# Altium schematic sheet 4) TEMP & WATCHDOG.SchDoc("4) TEMP & WATCHDOG")
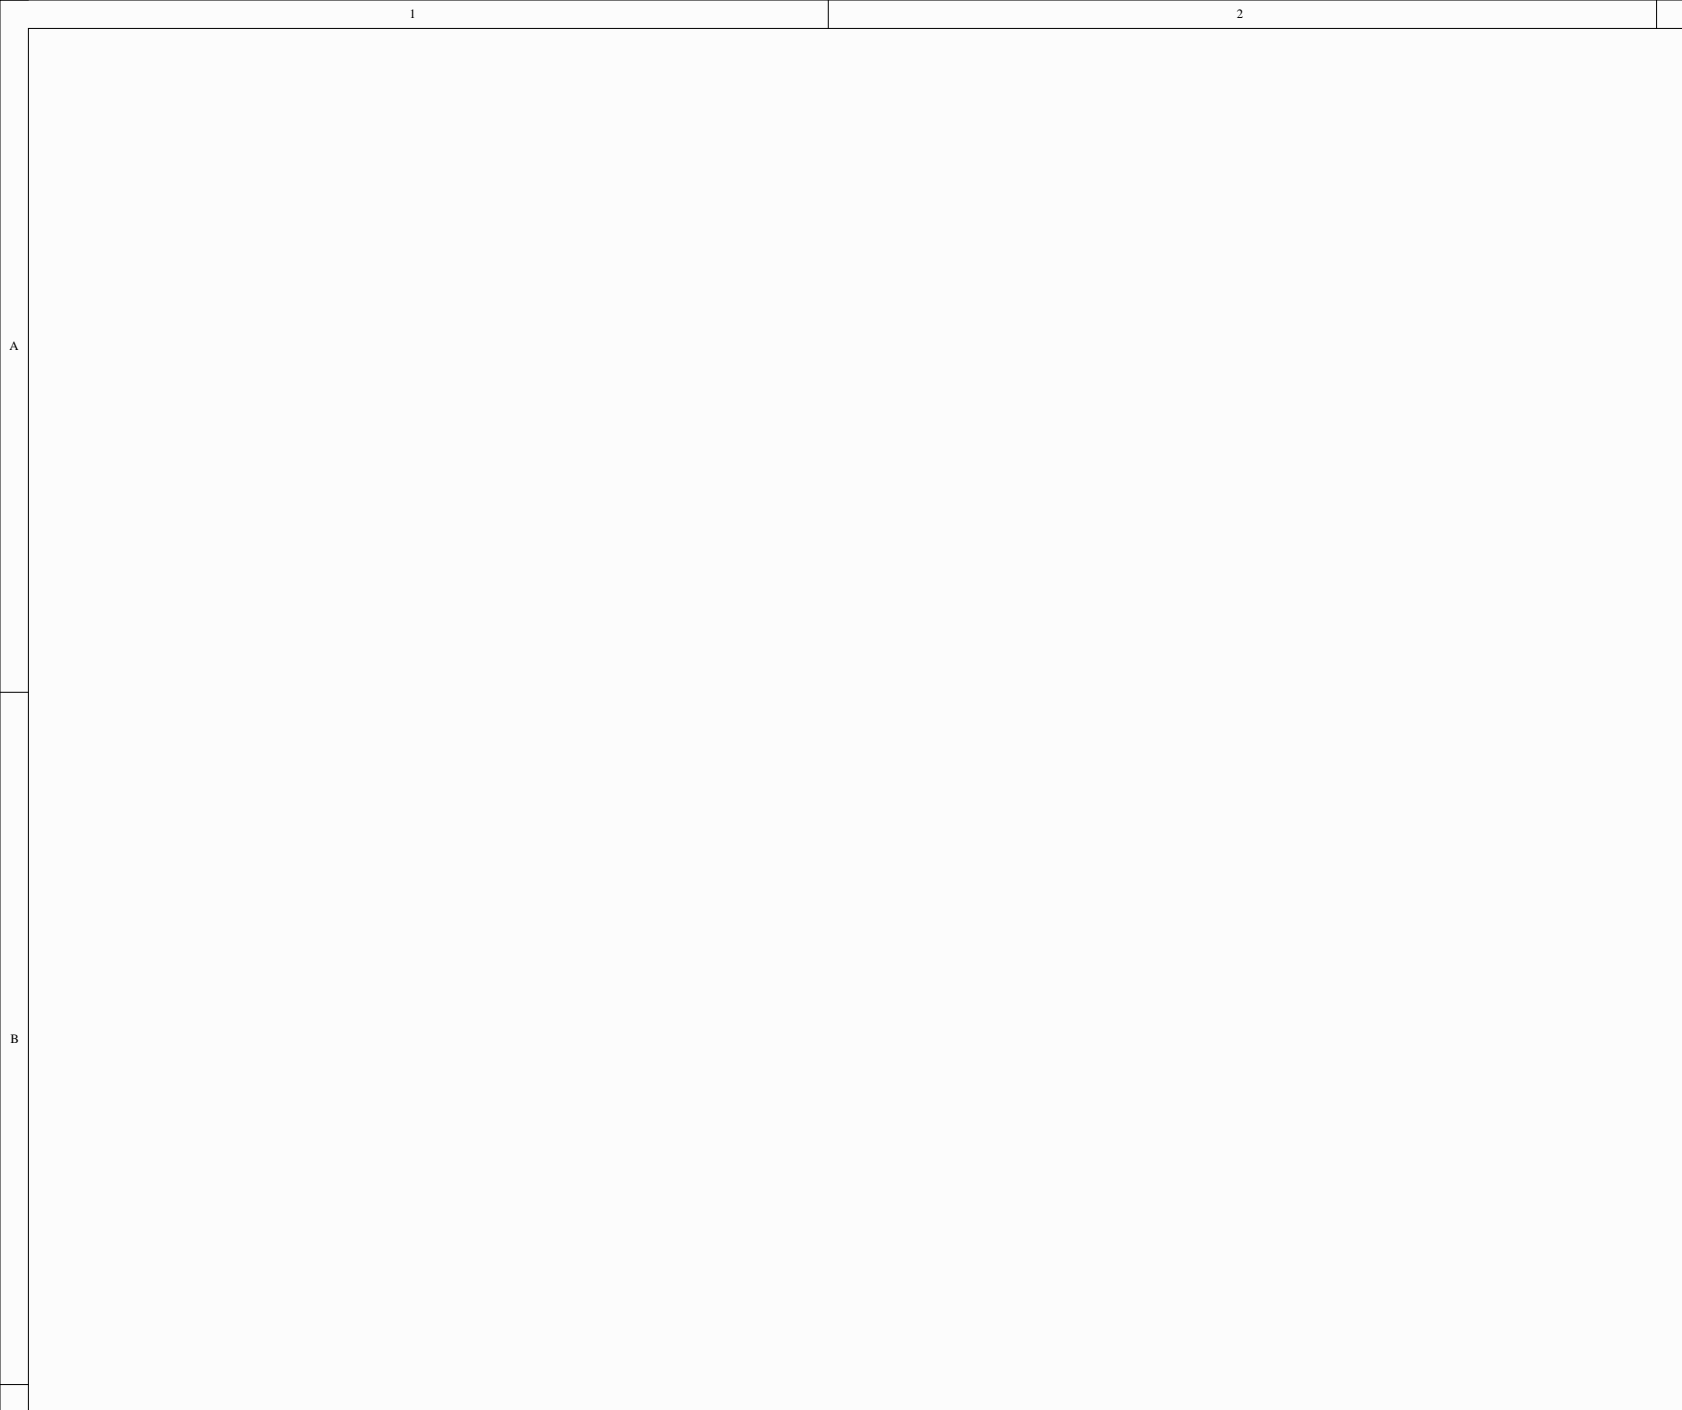
[diagram p0: 4) TEMP & WATCHDOG.SchDoc, top left]
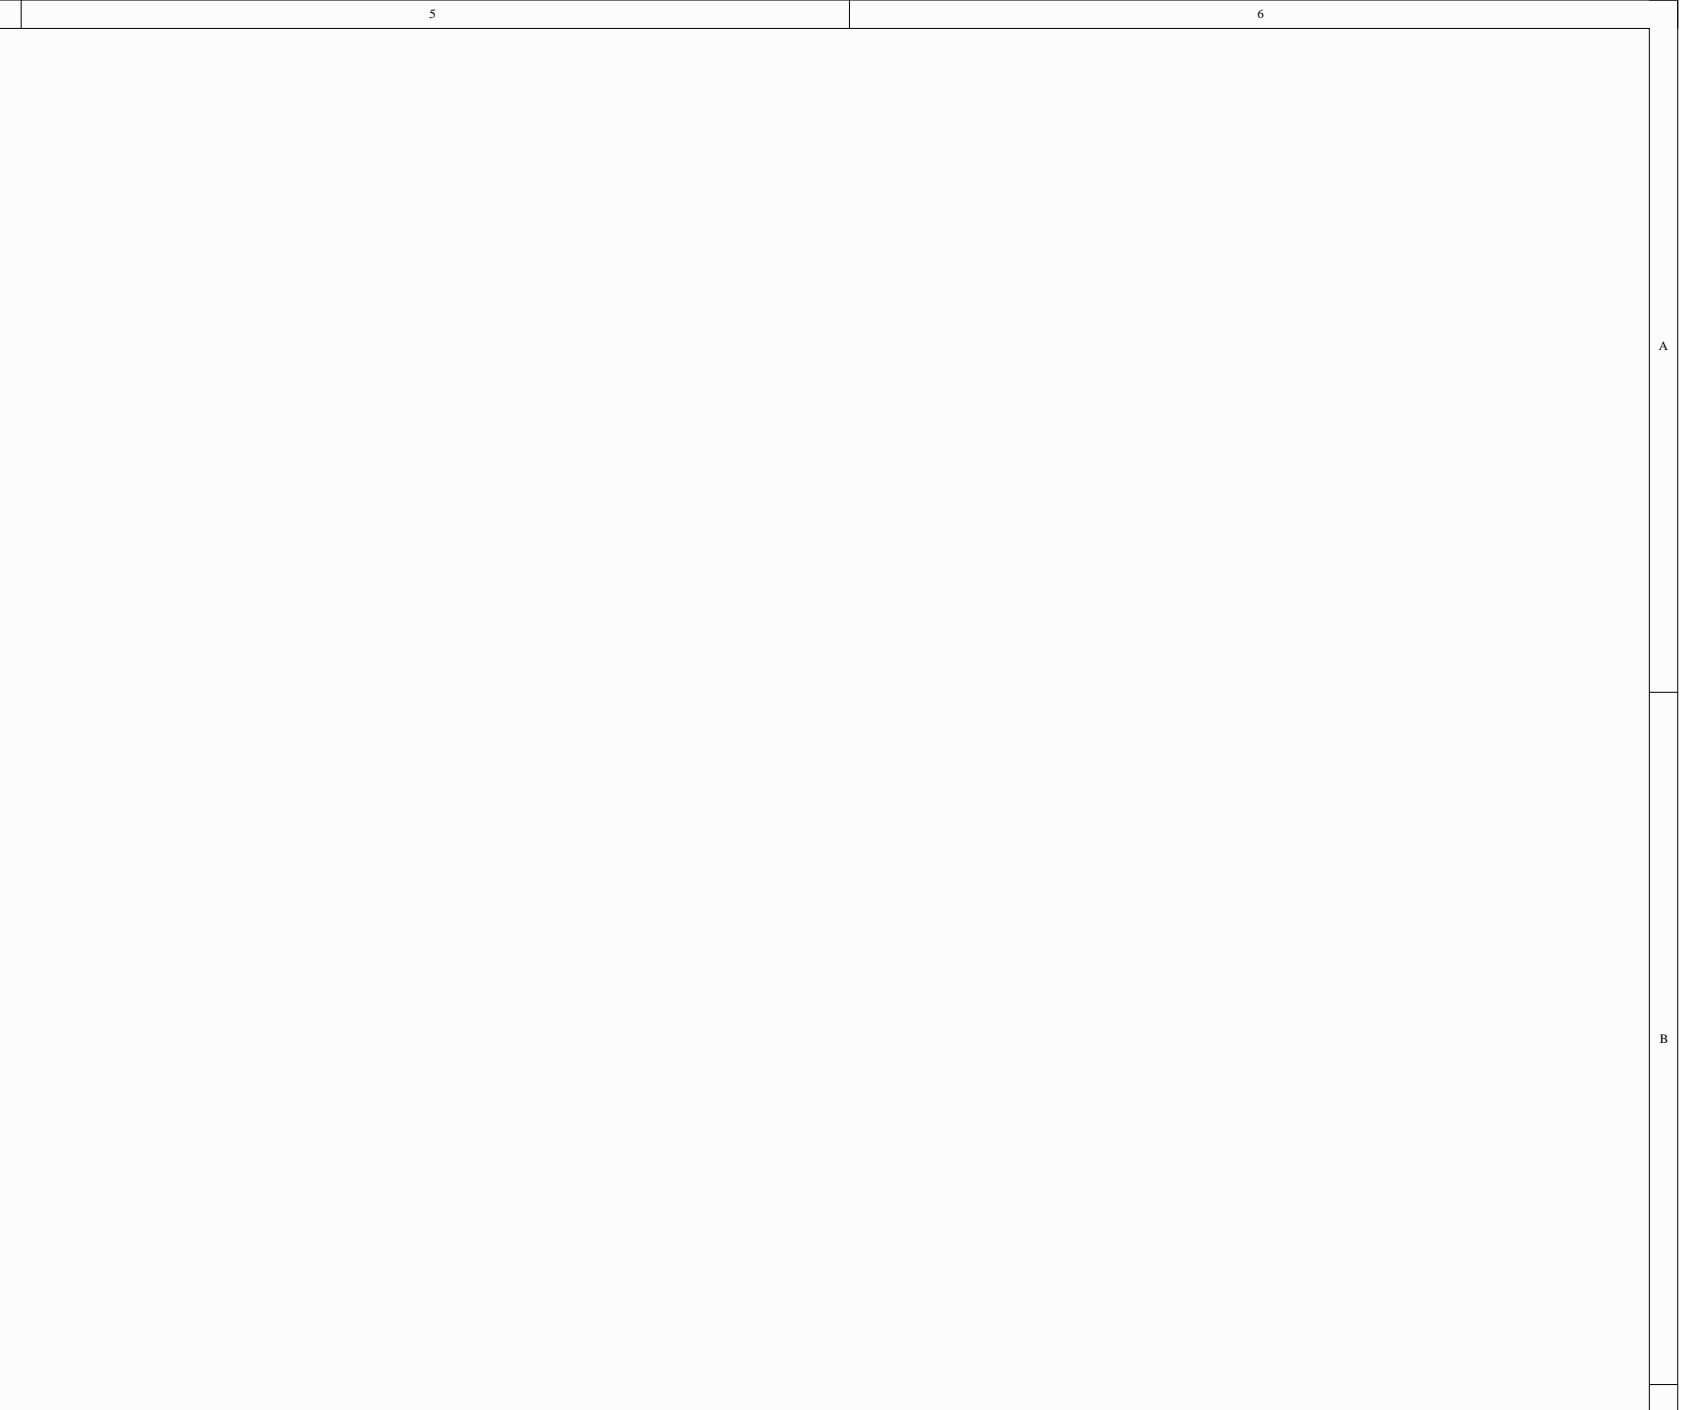
[diagram p1: 4) TEMP & WATCHDOG.SchDoc, top right]
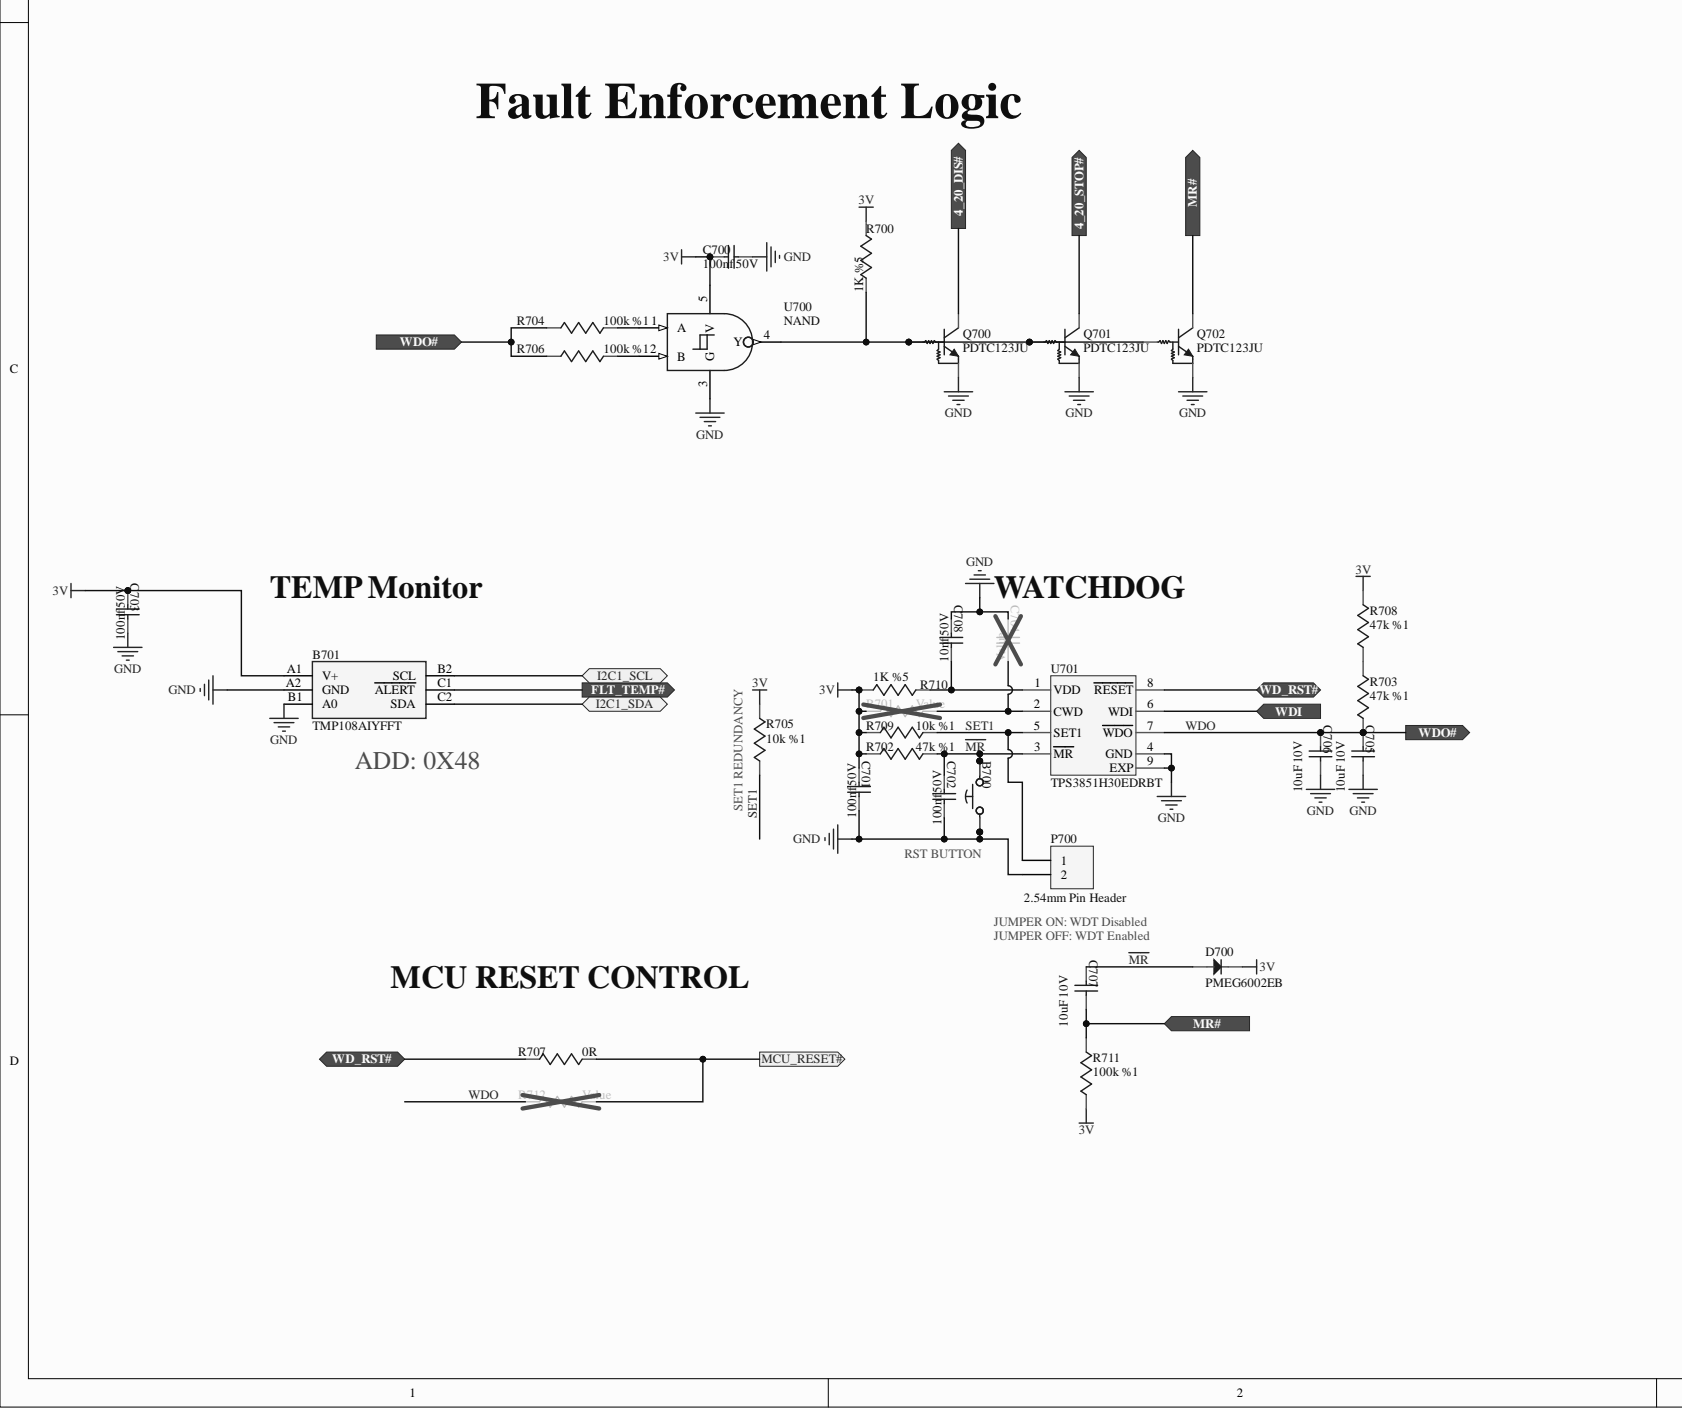
[diagram p2: 4) TEMP & WATCHDOG.SchDoc, bottom left]
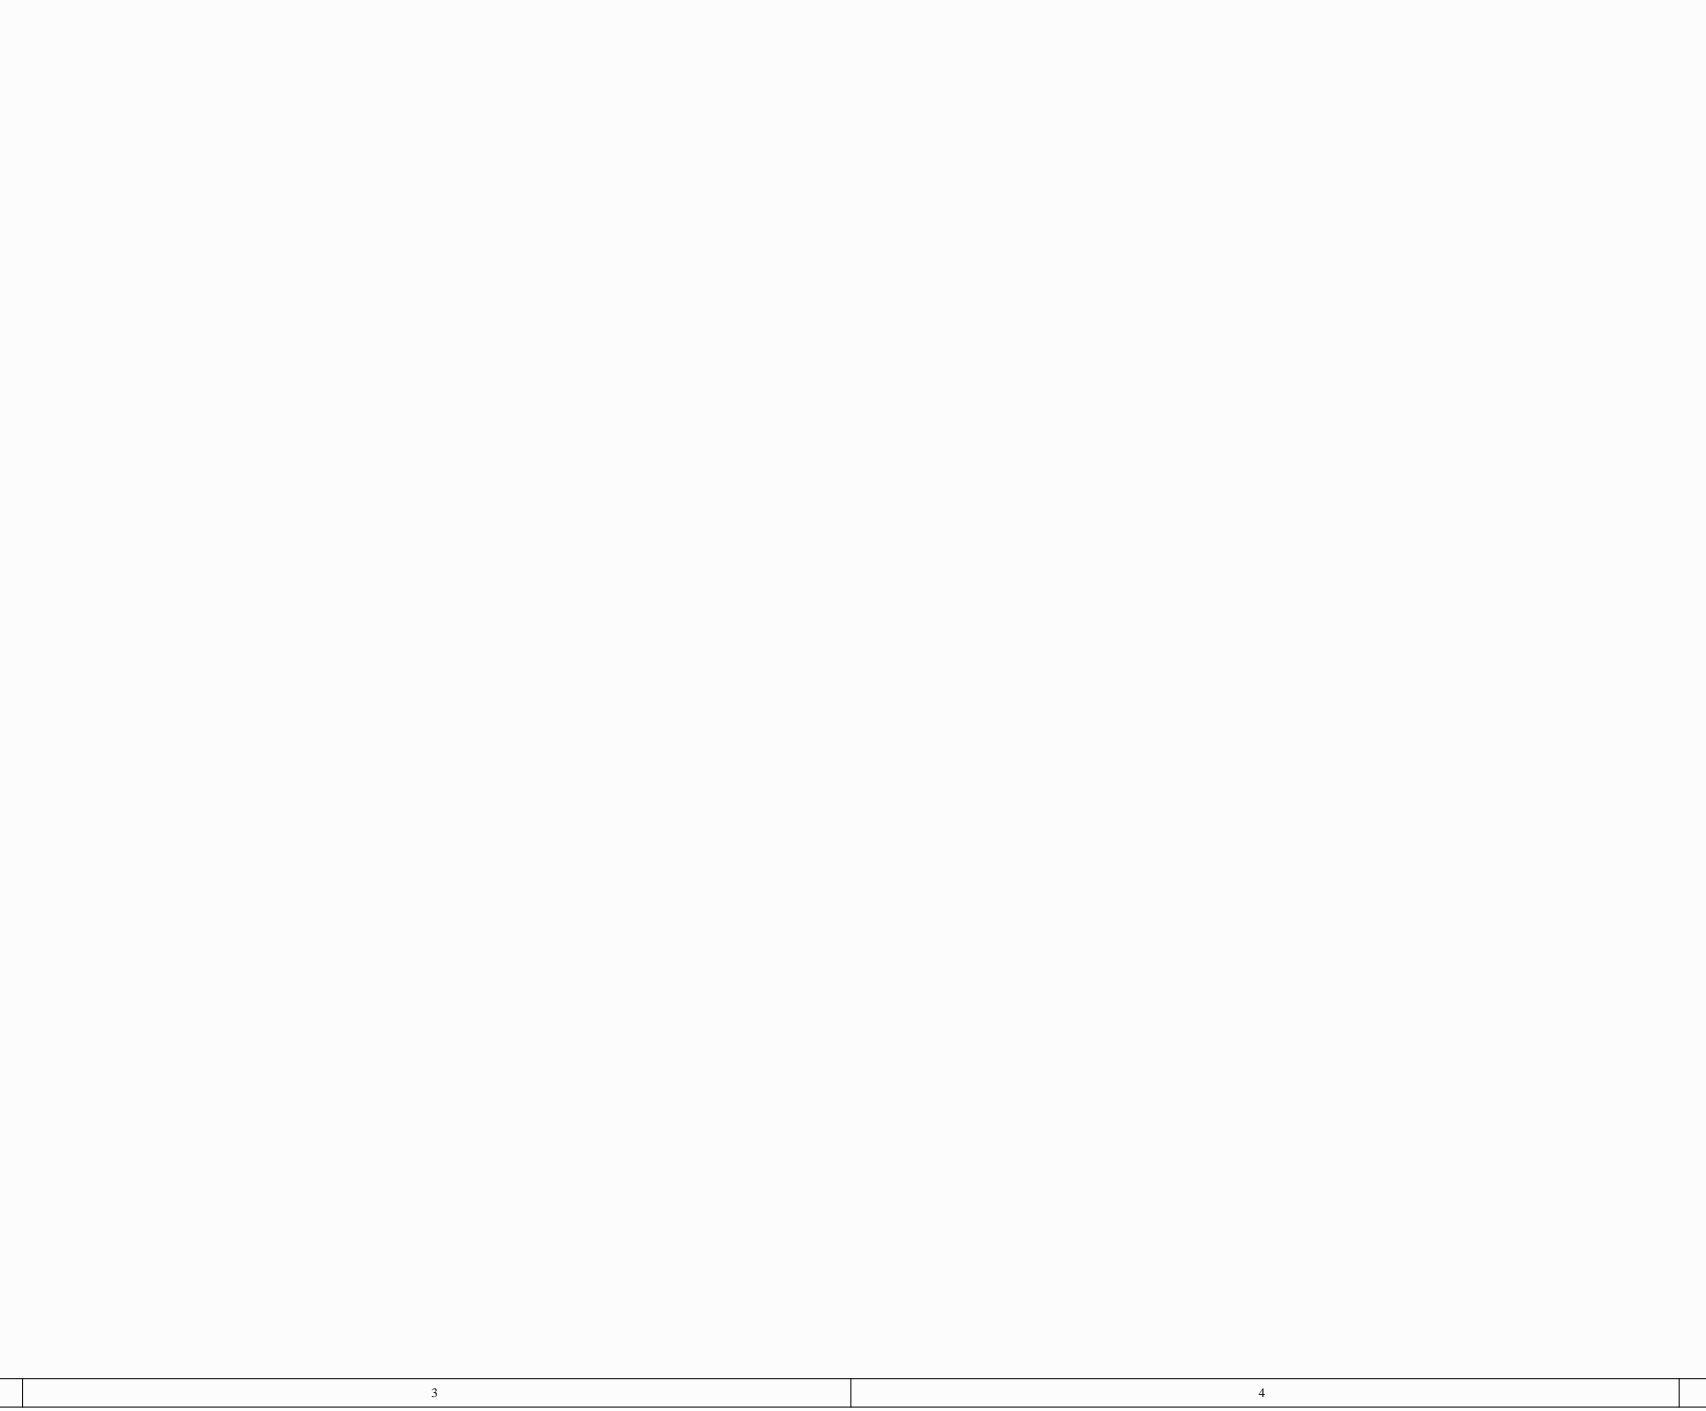
[diagram p3: 4) TEMP & WATCHDOG.SchDoc, bottom centre]
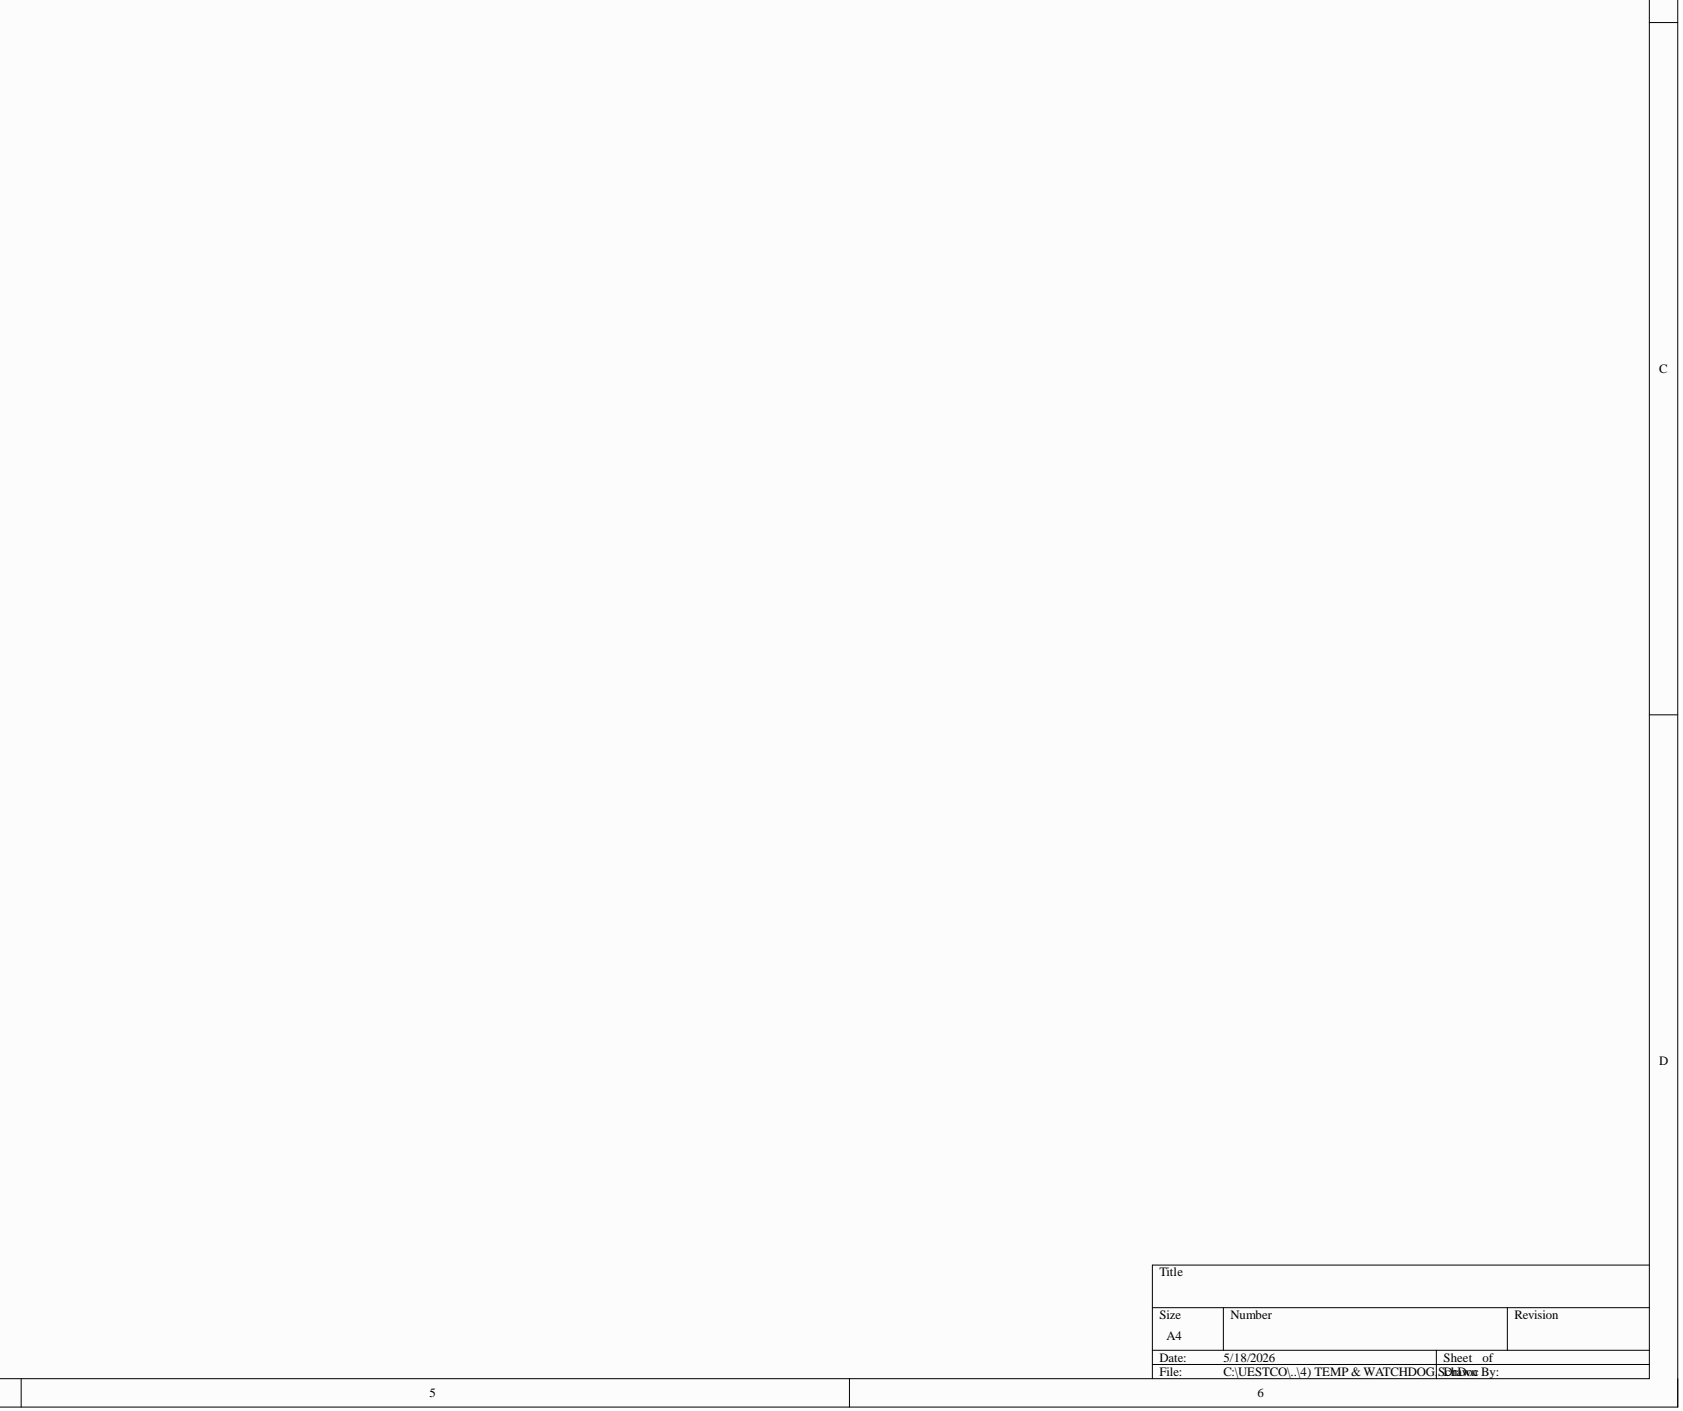
[diagram p4: 4) TEMP & WATCHDOG.SchDoc, bottom right]

## Parts
B700  Button  [Button 2.7x3mmx1.4mm]  (value not drawn)
B701  TMP108AIYFFT  [BGA6C40P2X3_79X119X62]
C700  100nf 50V  [C0402]
C701  100nf 50V  [C0402]
C702  100nf 50V  [C0402]
C703  100nf 50V  [C0402]
C704  10nf 50V  [C0402]
C705  10uF 10V  [C0402]
C706  10uF 10V  [C0402]
C707  10uF 10V  [C0402]
C708  10nf 50V  [C0402]
D700  PMEG6002EB  [SOD-523]
P700  2.54mm Pin Header  [HDR1X2]
Q700  PDTC123JU  [SOT323, SC70]
Q701  PDTC123JU  [SOT323, SC70]
Q702  PDTC123JU  [SOT323, SC70]
R700  1K %5  [R0402]
R701  10k %1  [R0402]
R702  47k %1  [R0402]
R703  47k %1  [R0402]
R704  100k %1  [R0402]
R705  10k %1  [R0402]
R706  100k %1  [R0402]
R707  0R  [R0402]
R708  47k %1  [R0402]
R709  10k %1  [R0402]
R710  1K %5  [R0402]
R711  100k %1  [R0402]
R712  0R  [R0402]
U700  NAND  [DCK5]
U701  TPS3851H30EDRBT  [8-SON (3x3)]

## Ports
4_20_DIS#, 4_20_STOP#, FLT_TEMP#, I2C1_SCL, I2C1_SDA, MCU_RESET#, MR#, WD_RST#, WDI, WDO#

## Nets
3V: B701.A1(V+) C700.1 C701.1 C703.1 D700.1 R700.2 R701.1 R702.2 R705.2 R708.1 R709.1 R710.2 R711.1 U700.5(V)
GND: B700.1 B700.2 B701.A2(GND) B701.B1(A0) C700.2 C701.2 C702.2 C703.2 C704.2 C705.2 C706.2 C708.2 P700.2 Q700.2 Q701.2 Q702.2 U700.3(G) U701.4(GND) U701.9(EXP)
4_20_DIS#: Q700.3 ; port 4_20_DIS#
4_20_STOP#: Q701.3 ; port 4_20_STOP#
FLT_TEMP#: B701.C1(ALERT) ; port FLT_TEMP#
I2C1_SCL: B701.B2(SCL) ; port I2C1_SCL
I2C1_SDA: B701.C2(SDA) ; port I2C1_SDA
~{MR}: B700.3 B700.4 C702.1 C707.1 D700.2 R702.1 U701.3(MR)
MCU_RESET#: R707.2 R712.2 ; port MCU_RESET#
MR#: C707.2 Q702.3 R711.2 ; port MR#
SET1: P700.1 R705.1 R709.2 U701.5(SET1)
WD_RST#: R707.1 U701.8(RESET) ; port WD_RST#
WDI: U701.6(WDI) ; port WDI
WDO: C705.1 C706.1 R703.2 R704.2 R706.2 R712.1 U701.7(WDO) ; port WDO#
NetC704_1: C704.1 R701.2 U701.2(CWD)
NetC708_1: C708.1 R710.1 U701.1(VDD)
NetQ700_1: Q700.1 Q701.1 Q702.1 R700.1 U700.4(Y)
NetR703_1: R703.1 R708.2
NetR704_1: R704.1 U700.1(A)
NetR706_1: R706.1 U700.2(B)

## Library data of the parts
B700 = Button 2.7*3.0mm 1.4mm: Tactile Switches Vertical Push Type 2.7*3.0; Manufacturer Part Number: TBE-Q-T/R; Component Kind: Standard; Library Name: PCBGSM910G2.SCHLIB; Mouser No: 113-TBEQTR; Value: Button
B701 = TMP108AIYFFT: 1.4V-Capable +/-0.75C Temperature Sensor with Window Alert Function and I2C/SMBus in WCSP; Manufacturer_Name: Texas Instruments; Geometry.Height: 0.625mm; Arrow Part Number: TMP108AIYFFT; Arrow Price/Stock: https://www.arrow.com/en/products/tmp108aiyfft/texas-instruments; Component Kind: Standard; Datasheet Link: http://www.ti.com/lit/gpn/TMP108; Library Name: TMP108AIYFFT.SchLib; Manufacturer_Part_Number: TMP108AIYFFT; Mouser Part Number: 595-TMP108AIYFFT; Mouser Price/Stock: https://www.mouser.co.uk/ProductDetail/Texas-Instruments/TMP108AIYFFT?qs=aG%252B9dtamCDeYP64H8EZq%2FA%3D%3D
C700-C703 = CAP 100nf 50V %10 0402: CAP CER 0.1UF 50V X5R 0402; Manufacturer Part Number: CC0402KRX5R9BB104; Component Kind: Standard; Library Name: PCBGSM910G2.SCHLIB; Value: 100nf 50V
C704 C708 = CAP 10nf 50V %10 0402: CAP CER 10000PF 50V X7R 0402; Manufacturer Part Number: CC0402KRX7R9BB103; Component Kind: Standard; Library Name: CAP LIB.SchLib; Value: 10nf 50V
C705-C707 = CAP 100nf 50V %10 0402: CAP CER 10UF 10V X5R 0402; Manufacturer Part Number: CL05A106MP5NUNC; Component Kind: Standard; Library Name: PCBGSM910G2.SCHLIB; Value: 10uF 10V
D700 = Diode PMEG6002EB,115: DIODE SCHOTTKY 60V 200MA SOD523; Component Kind: Standard; Library Name: Diode LIB.SchLib; Manufacturer Part Number: PMEG6002EB,115; Value: PMEG6002EB
P700 = Header 2: Header, 2-Pin; Manufacturer Part Number: M20-9990246; Component Kind: Standard; LatestRevisionDate: 17-Jul-2002; LatestRevisionNote: Re-released for DXP Platform.; Library Name: Miscellaneous Connectors.IntLib; Signal Integrity: Connector; Value: 2.54mm Pin Header; Publisher: Altium Limited
Q700-Q702 = TRANS PDTC123JU,115 NPN: TRANS PREBIAS NPN 50V SOT323; Component Kind: Standard; Library Name: PCBGSM910G2.SCHLIB; Manufacturer Part Number: PDTC123JU,115; Value: PDTC123JU
R700 R710 = RES 1K %5 1/16W 0402: RES SMD 1K OHM 5% 1/16W 0402; Manufacturer Part Number: RC0402JR-071KL; Component Kind: Standard; Library Name: PCBGSM910G2.SCHLIB; Value: 1K %5
R701 R705 R709 = RES 10k %1 1/16W 0402: RES SMD 10K OHM 1% 1/16W 0402; Manufacturer Part Number: RC0402FR-0710KP; Component Kind: Standard; Library Name: PCBGSM910G2.SCHLIB; Value: 10k %1
R702 R703 R708 = RES 47k %1 1/16W 0402: RES SMD 47K OHM 1% 1/16W 0402; Manufacturer Part Number: RC0402FR-0747KL; Component Kind: Standard; Library Name: PCBGSM910G2.SCHLIB; Value: 47k %1
R704 R706 R711 = RES 100K %1 1/16W 0402: RES SMD 100K OHM 1% 1/16W 0402; Manufacturer Part Number: RC0402FR-07100KL; Component Kind: Standard; Library Name: RES LIB.SchLib; Value: 100k %1
R707 R712 = RES 0R 1/16W 0402: RES SMD 0 OHM JUMPER 1/16W 0402; Manufacturer Part Number: RC0402JR-070RL; Component Kind: Standard; Library Name: PCBGSM910G2.SCHLIB; Value: 0R
U700 = SN74LVC1G132DCKR: Single 2-input, 1.65-V to 5.5-V NAND gate with Schmitt-Trigger inputs 5-SC70 -40 to 125; Manufacturer_Name: Texas Instruments; Component Kind: Standard; Library Name: SN74LVC1G132DCKR.SchLib; Manufacturer Part Number: SN74LVC1G132DCKR; RefDes: RefDes; Type: Type; Value: NAND; Copyright: Copyright (C) 2025 Ultra Librarian. All rights reserved.
U701 = SUPERVISOR TPS3851H30EDRBT: IC SUPERVISOR 1 CHANNEL 8SON; Component Kind: Standard; ComponentLink1Description: Link; ComponentLink1URL: https://www.ti.com/lit/ds/symlink/tps3851.pdf?ts=1759820100522; Library Name: PCBGSM910G2.SCHLIB; Manufacturer Part Number: TPS3851H30EDRBT; Value: TPS3851H30EDRBT
